# Altium schematic sheet Peripherals.SchDoc(Peripherals)
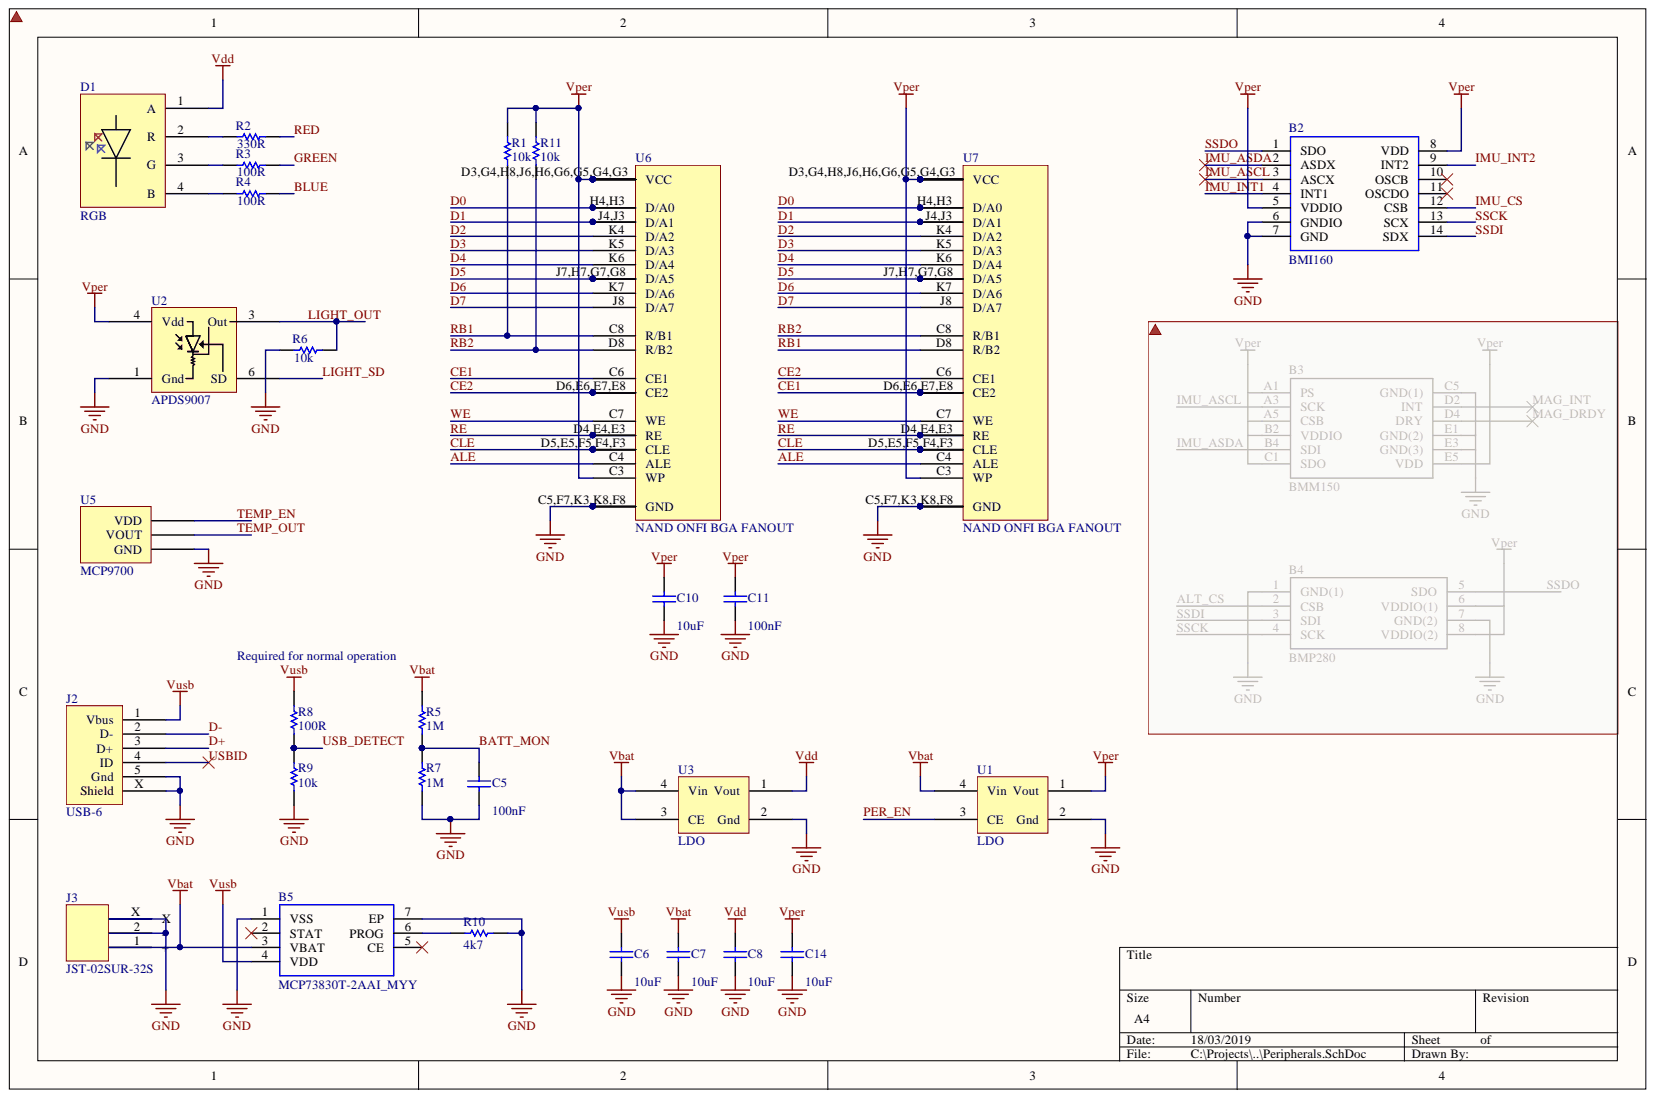
[diagram p0: Peripherals.SchDoc]

## Parts
B2  BMI160  [PQFN50P300X250X88-14N]
B5  MCP73830T-2AAI_MYY  [SON50P200X200X80-7N-D]
C5  100nF  [0402c]
C6  10uF  [1608[0603]]
C7  10uF  [1608[0603]]
C8  10uF  [1608[0603]]
C10  10uF  [1608[0603]]
C11  100nF  [0402c]
C14  10uF  [1608[0603]]
D1  RGB  [RGBLED]
J2  USB-6  [JST   UB-MC5BR3-SDWP604-4S]
J3  JST-02SUR-32S  [JST-02SUR-32S-Batt]
R1  10k  [0402]
R2  330R  [0402]
R3  100R  [0402]
R4  100R  [0402]
R5  1M  [0402]
R6  10k  [0402]
R7  1M  [0402]
R8  100R  [0402]
R9  10k  [0402]
R10  4k7  [0402]
R11  10k  [0402]
U1  LDO  [UDFN1010]
U2  APDS9007  [APDS9007]
U3  LDO  [UDFN1010]
U5  MCP9700  [SC70-5]
U6  NAND ONFI BGA FANOUT  [BGA63-11x9_No_VSSP]
U7  NAND ONFI BGA FANOUT  [BGA63-11x9_No_VSSP]

## Nets
GND: B2.6(GNDIO) B2.7(GND) B5.1(VSS) B5.7(EP) C5.1 C6.1 C7.1 C8.1 C10.1 C11.1 C14.1 J2.5(Gnd) J2.X(Shield) J3.2 J3.X R6.1 R7.1 R9.1 R10.1 U1.2(Gnd) U2.1(Gnd) U3.2(Gnd) U5.2 U6.C5,F7,K3,K8,F8(GND) U6.F7 U6.F8 U6.K3 U6.K8 U7.C5,F7,K3,K8,F8(GND) U7.F7 U7.F8 U7.K3 U7.K8
Vbat: B5.3(VBAT) C7.2 J3.1 R5.2 U1.4(Vin) U3.3(CE) U3.4(Vin)
Vdd: C8.2 D1.1(A) U3.1(Vout)
Vper: B2.5(VDDIO) B2.8(VDD) C10.2 C11.2 C14.2 R1.2 R11.2 U1.1(Vout) U2.4(Vdd) U6.C3(WP) U6.D3,G4,H8,J6,H6,G6,G5,G4,G3(VCC) U6.G3 U6.G4 U6.G5 U6.G6 U6.H6 U6.H8 U6.J6 U7.C3(WP) U7.D3,G4,H8,J6,H6,G6,G5,G4,G3(VCC) U7.G3 U7.G4 U7.G5 U7.G6 U7.H6 U7.H8 U7.J6
Vusb: B5.4(VDD) C6.2 J2.1(Vbus) R8.2
ALE: U6.C4(ALE) U7.C4(ALE)
BATT_MON: C5.2 R5.1 R7.2
BLUE: R4.2
CE1: U6.C6(CE1) U7.D6,E6,E7,E8(CE2) U7.E6 U7.E7 U7.E8
CE2: U6.D6,E6,E7,E8(CE2) U6.E6 U6.E7 U6.E8 U7.C6(CE1)
CLE: U6.D5,E5,F5,F4,F3(CLE) U6.E5 U6.F3 U6.F4 U6.F5 U7.D5,E5,F5,F4,F3(CLE) U7.E5 U7.F3 U7.F4 U7.F5
D0: U6.H3 U6.H4,H3(D/A0) U7.H3 U7.H4,H3(D/A0)
D1: U6.J3 U6.J4,J3(D/A1) U7.J3 U7.J4,J3(D/A1)
D2: U6.K4(D/A2) U7.K4(D/A2)
D3: U6.K5(D/A3) U7.K5(D/A3)
D4: U6.K6(D/A4) U7.K6(D/A4)
D5: U6.G7 U6.G8 U6.H7 U6.J7,H7,G7,G8(D/A5) U7.G7 U7.G8 U7.H7 U7.J7,H7,G7,G8(D/A5)
D6: U6.K7(D/A6) U7.K7(D/A6)
D7: U6.J8(D/A7) U7.J8(D/A7)
D+: J2.3(D+)
D-: J2.2(D-)
GREEN: R3.2
IMU_ASCL: B2.3(ASCX)
IMU_ASDA: B2.2(ASDX)
IMU_CS: B2.12(CSB)
IMU_INT1: B2.4(INT1)
IMU_INT2: B2.9(INT2)
LIGHT_OUT: R6.2 U2.3(Out)
LIGHT_SD: U2.6(SD)
PER_EN: U1.3(CE)
RB1: R1.1 U6.C8(R/B1) U7.D8(R/B2)
RB2: R11.1 U6.D8(R/B2) U7.C8(R/B1)
RE: U6.D4,E4,E3(RE) U6.E3 U6.E4 U7.D4,E4,E3(RE) U7.E3 U7.E4
RED: R2.2
SSCK: B2.13(SCX)
SSDI: B2.14(SDX)
SSDO: B2.1(SDO)
TEMP_EN: U5.4
TEMP_OUT: U5.3
USB_DETECT: R8.1 R9.2
USBID: J2.4(ID)
WE: U6.C7(WE) U7.C7(WE)
NetB5_6: B5.6(PROG) R10.2
NetD1_2: D1.2(R) R2.1
NetD1_3: D1.3(G) R3.1
NetD1_4: D1.4(B) R4.1

Not connected: B2 10(OSCB) 11(OSCDO); B5 2(STAT) 5(CE)

## Library data of the parts
B2 = BMI160: Inertial Measurement Units; Manufacturer_Name: Bosch Sensortec; Value: BMI160; Supplier Part Number 1: 828-1057-1-ND; Supplier 1: Digi-Key; Manufacturer Part Number 1: BMI160; Library Name: BMI160.SchLib; Datasheet Link: https://ae-bst.resource.bosch.com/media/products/dokumente/bmi160/BST-BMI160-DS000-07.pdf; Component Kind: Standard
B5 = MCP73830T-2AAI_MYY: Battery Management 1A LI-ION BATTERY CHARGE MGNT CNTRLR; Manufacturer Part Number 1: MCP73830T-2AAI/MYY; Manufacturer_Name: Microchip; Geometry.Height: 0.8mm; Value: MCP73830T-2AAI_MYY; Supplier Part Number 1: 455-2039-1-ND; Supplier 1: Digi-Key; Library Name: MCP73830T-2AAI_MYY.SchLib; Datasheet Link: http://www.microchip.com/mymicrochip/filehandler.aspx?ddocname=en555820; Component Kind: Standard
C5 C11 = Cap: Capacitor; Manufacturer Part Number 1: 100nF 16v X7R or better e.g. GRM155R71C104MA88D; Value: 100nF; Supplier Part Number 1: GRM155R71C104MA88D-ND; Supplier 1: Digi-key; Simulation: CAP; Signal Integrity: Cap; Published: 8-Jun-2000; PackageDescription: Radial Cap, Thru-Hole; 2 Leads; 0.3 in Pin Spacing; Library Name: Miscellaneous Devices.IntLib; LatestRevisionNote: Re-released for DXP Platform.; LatestRevisionDate: 17-Jul-2002; Component Kind: Standard; Publisher: Altium Limited
C6-C8 C10 C14 = Cap: Capacitor; Manufacturer Part Number 1: 10uF 10v X5R or better e.g. GRM188R61A106KE69J; Value: 10uF; Supplier Part Number 1: 490-14372-2-ND; Supplier 1: Digi-key; Simulation: CAP; Signal Integrity: Cap; Published: 8-Jun-2000; PackageDescription: Radial Cap, Thru-Hole; 2 Leads; 0.3 in Pin Spacing; Library Name: Miscellaneous Devices.IntLib; LatestRevisionNote: Re-released for DXP Platform.; LatestRevisionDate: 17-Jul-2002; Component Kind: Standard; Publisher: Altium Limited
D1 = RGB: RGB LED CA; Manufacturer Part Number 1: APTF1616SEEZGQBDC; Supplier Part Number 1: 754-1500-1-ND; Supplier 1: Digi-Key; Component Kind: Standard; Library Name: RGBLEDCA.SchLib
J2 = USB-6: uUSB Connector; Manufacturer Part Number 1: UB-MC5BR3-SDWP604-4S-TF; Supplier Part Number 1: 455-2932-1-ND; Supplier 1: Digi-Key; Library Name: USB.SchLib; Component Kind: Standard
J3 = JST-02SUR-32S: Battery-Mate-JST-02SUR-32S; Manufacturer Part Number 1: SM02B-SURS-TF(LF)(SN); Supplier Part Number 1: 455-2039-1-ND; Supplier 1: Digi-Key; Library Name: JST-SUR.SchLib; Component Kind: Standard
R1 R6 R9 R11 = Res1: Resistor; Manufacturer Part Number 1: 10k, Generic e.g. CRCW040210K0JNEDC; Value: 10k; Supplier Part Number 1: 541-3958-2-ND; Supplier 1: Digi-key; Simulation: RESISTOR; Signal Integrity: Res; Published: 8-Jun-2000; PackageReference: AXIAL-0.3; PackageDescription: Axial Device, Thru-Hole; 2 Leads; 0.3 in Pin Spacing; Library Name: Miscellaneous Devices.IntLib; LatestRevisionNote: Re-released for DXP Platform.; LatestRevisionDate: 17-Jul-2002; Component Kind: Standard; Publisher: Altium Limited
R2 = Res1: Resistor; Manufacturer Part Number 1: 330r, Generic e.g. CRCW0402330RJNEDC; Value: 330R; Supplier Part Number 1: 541-4123-2-ND; Supplier 1: Digi-key; Simulation: RESISTOR; Signal Integrity: Res; Published: 8-Jun-2000; PackageDescription: Axial Device, Thru-Hole; 2 Leads; 0.3 in Pin Spacing; Library Name: Miscellaneous Devices.IntLib; LatestRevisionNote: Re-released for DXP Platform.; LatestRevisionDate: 17-Jul-2002; Component Kind: Standard; Publisher: Altium Limited
R3 R4 R8 = Res1: Resistor; Manufacturer Part Number 1: 100r, Generic e.g. CRCW0402100RJNEDC; Value: 100R; Supplier Part Number 1: 541-4010-2-ND; Supplier 1: Digi-key; Simulation: RESISTOR; Signal Integrity: Res; Published: 8-Jun-2000; PackageDescription: Axial Device, Thru-Hole; 2 Leads; 0.3 in Pin Spacing; Library Name: Miscellaneous Devices.IntLib; LatestRevisionNote: Re-released for DXP Platform.; LatestRevisionDate: 17-Jul-2002; Component Kind: Standard; Publisher: Altium Limited
  R3 R4: PackageReference: AXIAL-0.3
R5 R7 = Res1: Resistor; Manufacturer Part Number 1: 1Meg, Generic e.g. CRCW04021M00JNEDC; Value: 1M; Supplier Part Number 1: 541-4119-2-ND; Supplier 1: Digi-key; Simulation: RESISTOR; Signal Integrity: Res; Published: 8-Jun-2000; PackageReference: AXIAL-0.3; PackageDescription: Axial Device, Thru-Hole; 2 Leads; 0.3 in Pin Spacing; Library Name: Miscellaneous Devices.IntLib; LatestRevisionNote: Re-released for DXP Platform.; LatestRevisionDate: 17-Jul-2002; Component Kind: Standard; Publisher: Altium Limited
R10 = Res1: Resistor; Manufacturer Part Number 1: Generic 4.7k 0402 part e.g. CRCW04024K70JNEDC; Value: 4k7; Supplier Part Number 1: 541-3974-2-ND; Supplier 1: Digi-Key; Simulation: RESISTOR; Signal Integrity: Res; Library Name: Miscellaneous Devices.IntLib; Component Kind: Standard
U1 = LDO: V-Regulator 3v LDO; Value: NCP4682DMU30TCG; Supplier Part Number 1: NCP4682DMU30TCGOSCT-ND; Supplier 1: Digi-Key; Manufacturer Part Number 1: NCP4682DMU30TCG; Library Name: LDO-wCE.SchLib; Component Kind: Standard
U2 = APDS9007: Light sensor; Manufacturer Part Number 1: APDS-9007-020; Value: APDS9007; Supplier Part Number 1: 516-1719-6-ND; Supplier 1: Digi-Key; Library Name: AXG2_v1.SCHLIB; Component Kind: Standard
U3 = LDO: V-Regulator 3v LDO; Manufacturer Part Number 1: NCP4682DMU30TCGOSCT-ND; Value: NCP4682DMU30TCG; Supplier Part Number 1: NCP4682DMU30TCGOSCT-ND; Supplier 1: Digi-Key; Library Name: LDO-wCE.SchLib; Component Kind: Standard
U5 = MCP9700: Linear thermistor; Manufacturer Part Number 1: MCP9700T-E/LT; Supplier Part Number 1: MCP9700T-E/LTCT-ND; Supplier 1: Digi-Key; Library Name: AXG2_v1.SCHLIB; Component Kind: Standard
U6 U7 = NAND ONFI BGA FANOUT: NAND flash memory; Manufacturer Part Number 1: MX30LF4G18AC-XKI; Supplier Part Number 1: 1092-1167-ND; Supplier 1: Digi-Key; Library Name: AXG2_v1.SCHLIB; Component Kind: Standard
